# Altium schematic sheet BalEv_SD_card_v1_0.SchDoc
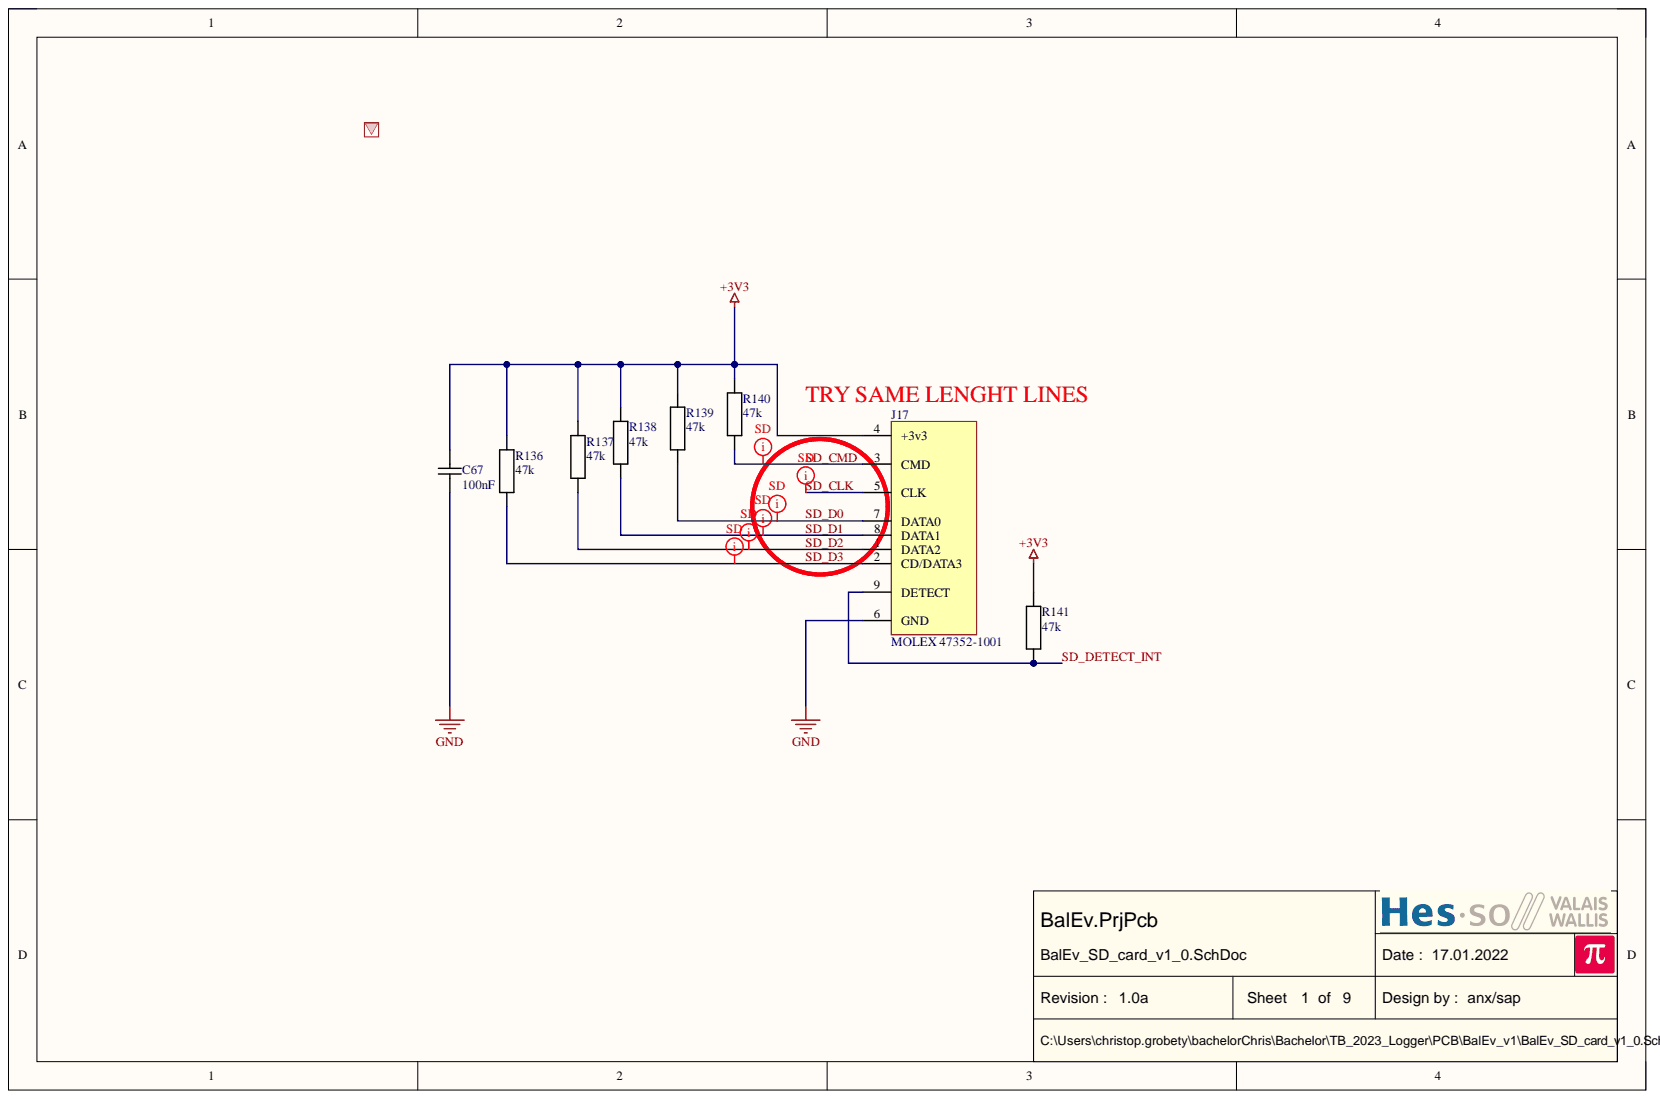
[diagram p0: BalEv_SD_card_v1_0.SchDoc]

## Parts
C67  100nF  [CAPACITOR 1005 REFLOW]
J17  MOLEX 47352-1001  [SD MICRO MOLEX 47352-1001]
R136  47k  [RESISTOR 1005 REFLOW]
R137  47k  [RESISTOR 1005 REFLOW]
R138  47k  [RESISTOR 1005 REFLOW]
R139  47k  [RESISTOR 1005 REFLOW]
R140  47k  [RESISTOR 1005 REFLOW]
R141  47k  [RESISTOR 1005 REFLOW]

## Nets
+3V3: C67.2 J17.4(+3v3) R136.2 R137.2 R138.2 R139.2 R140.2 R141.2
GND: C67.1 J17.6(GND)
SD_CLK: J17.5(CLK)
SD_CMD: J17.3(CMD) R140.1
SD_D0: J17.7(DATA0) R139.1
SD_D1: J17.8(DATA1) R138.1
SD_D2: J17.1(DATA2) R137.1
SD_D3: J17.2(CD/DATA3) R136.1
SD_DETECT_INT: J17.9(DETECT) R141.1

## Library data of the parts
C67 = Capacitor: Component Kind: Standard; Description: Capacitor; Library Name: Capacitor.SchLib; STOCK: NO; Value: 100nF
J17 = SD-MICRO MOLEX 47352-1001: SD Card  Micro 47352-1001; Component Kind: Standard; Library Name: Connector.SchLib; STOCK: YES; Value: MOLEX 47352-1001
R136-R141 = Resistor: Component Kind: Standard; Description: Resistor; Library Name: Resistor.SchLib; STOCK: NO; Value: 47k
